# Altium schematic sheet HUB.SchDoc
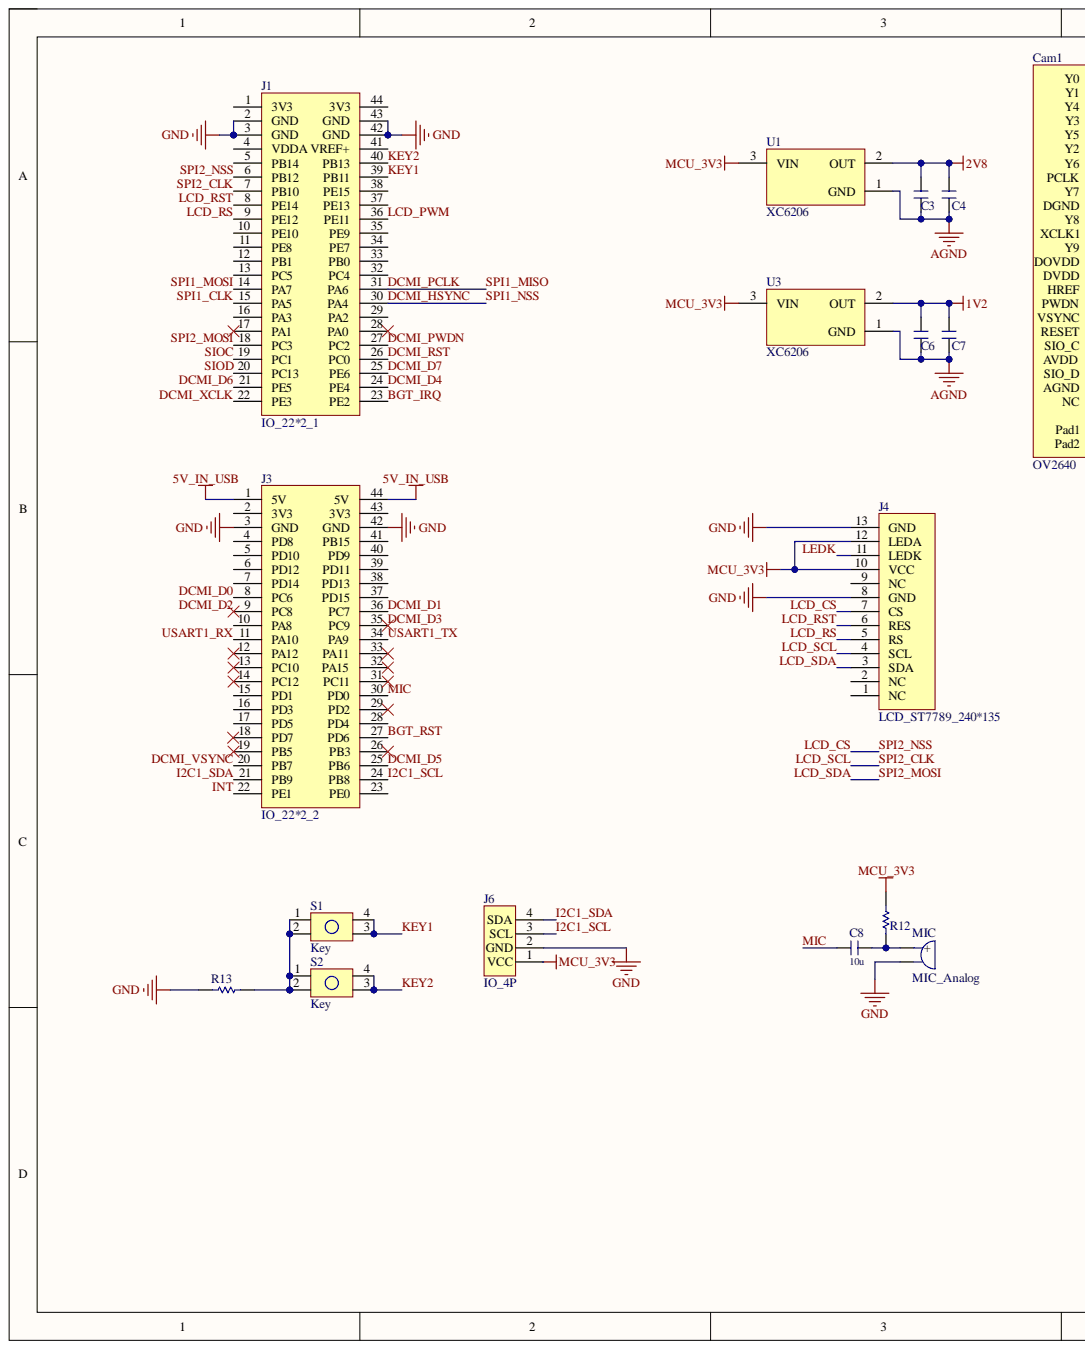
[diagram p0: HUB.SchDoc, left]
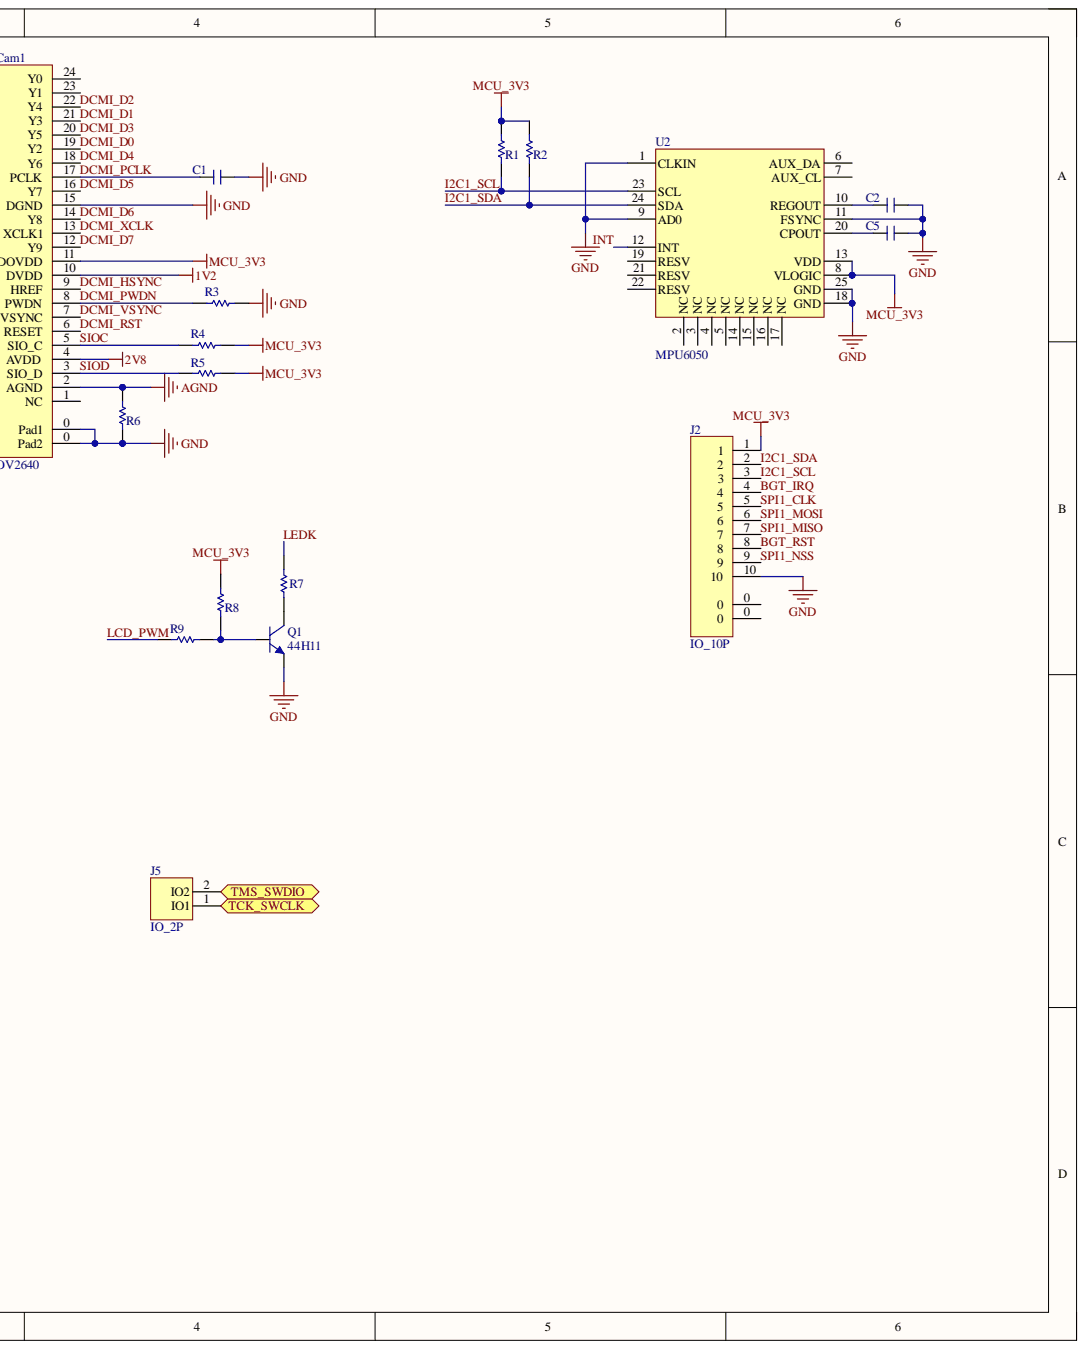
[diagram p1: HUB.SchDoc, right]

## Parts
C1  CAP  [0603_C_Axon]  (value not drawn)
C2  CAP  [0603_C_Axon]  (value not drawn)
C3  CAP  [0603_C_Axon]  (value not drawn)
C4  CAP  [0603_C_Axon]  (value not drawn)
C5  CAP  [0603_C_Axon]  (value not drawn)
C6  CAP  [0603_C_Axon]  (value not drawn)
C7  CAP  [0603_C_Axon]  (value not drawn)
C8  10u  [0603_C_Axon]
Cam1  OV2640  [FPC0.5 2H-WS-24P]
J1  IO_22*2_1  [IDE2X22]
J2  IO_10P  [FPC0.5 2H-WS-10P]
J3  IO_22*2_2  [IDE2X22]
J4  LCD_ST7789_240*135  [FPC_LCD_240*135]
J5  IO_2P  [HDR2.54-M-LI-2P]
J6  IO_4P  [ZH1.5-WS-4P]
MIC  MIC_Analog  [MIC]
Q1  44H11  [SOT_23_3_L_A]
R1  RES  [0603_R_Axon]  (value not drawn)
R2  RES  [0603_R_Axon]  (value not drawn)
R3  RES  [0603_R_Axon]  (value not drawn)
R4  RES  [0603_R_Axon]  (value not drawn)
R5  RES  [0603_R_Axon]  (value not drawn)
R6  RES  [0603_R_Axon]  (value not drawn)
R7  RES  [0603_R_Axon]  (value not drawn)
R8  RES  [0603_R_Axon]  (value not drawn)
R9  RES  [0603_R_Axon]  (value not drawn)
R12  RES  [0603_R_Axon]  (value not drawn)
R13  RES  [0603_R_Axon]  (value not drawn)
S1  Key  [BUTTON_SMD_4P]
S2  Key  [BUTTON_SMD_4P]
U1  XC6206  [SOT23-3N]
U2  MPU6050  [QFN_24]
U3  XC6206  [SOT23-3N]

## Ports
TCK_SWCLK, TMS_SWDIO

## Nets
1V2: C6.2 C7.2 Cam1.10(DVDD) U3.2
2V8: C3.2 C4.2 Cam1.4(AVDD) U1.2
5V_IN_USB: J3.1(5V) J3.44(5V)
AGND: C3.1 C4.1 C6.1 C7.1 Cam1.2(AGND) R6.2 U1.1 U3.1
GND: C1.2 C2.2 C5.2 Cam1.0(Pad2) Cam1.15(DGND) J1.2(GND) J1.3(GND) J1.42(GND) J1.43(GND) J2.10 J3.3(GND) J3.42(GND) J4.8(GND) J4.13(GND) J6.2(GND) MIC.2 Q1.3 R3.2 R6.1 R13.1 U2.1(CLKIN) U2.9(AD0) U2.11(FSYNC) U2.18(GND) U2.25(GND)
MCU_3V3: Cam1.11(DOVDD) J2.1 J4.10(VCC) J4.12(LEDA) J6.1(VCC) R1.1 R2.1 R4.2 R5.2 R8.2 R12.2 U1.3 U2.8(VLOGIC) U2.13(VDD) U3.3
BGT_IRQ: J1.23(PE2) J2.4
BGT_RST: J2.8 J3.27(PD6)
DCMI_D0: Cam1.19(Y2) J3.8(PC6)
DCMI_D1: Cam1.21(Y3) J3.36(PC7)
DCMI_D2: Cam1.22(Y4) J3.9(PC8)
DCMI_D3: Cam1.20(Y5) J3.35(PC9)
DCMI_D4: Cam1.18(Y6) J1.24(PE4)
DCMI_D5: Cam1.16(Y7) J3.25(PB6)
DCMI_D6: Cam1.14(Y8) J1.21(PE5)
DCMI_D7: Cam1.12(Y9) J1.25(PE6)
DCMI_HSYNC: Cam1.9(HREF) J1.30(PA4) J2.9
DCMI_PCLK: C1.1 Cam1.17(PCLK) J1.31(PA6) J2.7
DCMI_PWDN: Cam1.8(PWDN) J1.27(PC2) R3.1
DCMI_RST: Cam1.6(RESET) J1.26(PC0)
DCMI_VSYNC: Cam1.7(VSYNC) J3.20(PB7)
DCMI_XCLK: Cam1.13(XCLK1) J1.22(PE3)
I2C1_SCL: J2.3 J3.24(PB8) J6.3(SCL) R1.2 U2.23(SCL)
I2C1_SDA: J2.2 J3.21(PB9) J6.4(SDA) R2.2 U2.24(SDA)
INT: J3.22(PE1) U2.12(INT)
KEY1: J1.39(PB11) S1.3 S1.4
KEY2: J1.40(PB13) S2.3 S2.4
LCD_CS: J1.6(PB12) J4.7(CS)
LCD_PWM: J1.36(PE11) R9.1
LCD_RS: J1.9(PE12) J4.5(RS)
LCD_RST: J1.8(PE14) J4.6(RES)
LCD_SCL: J1.7(PB10) J4.4(SCL)
LCD_SDA: J1.18(PC3) J4.3(SDA)
LEDK: J4.11(LEDK) R7.2
MIC: C8.1 J3.30(PD0)
SIOC: Cam1.5(SIO_C) J1.19(PC1) R4.1
SIOD: Cam1.3(SIO_D) J1.20(PC13) R5.1
SPI1_CLK: J1.15(PA5) J2.5
SPI1_MOSI: J1.14(PA7) J2.6
TCK_SWCLK: J5.1 ; port TCK_SWCLK
TMS_SWDIO: J5.2 ; port TMS_SWDIO
USART1_RX: J3.11(PA10)
USART1_TX: J3.34(PA9)
NetC2_1: C2.1 U2.10(REGOUT)
NetC5_1: C5.1 U2.20(CPOUT)
NetC8_2: C8.2 MIC.1 R12.1
NetQ1_1: Q1.1 R8.1 R9.2
NetQ1_2: Q1.2 R7.1
NetR13_2: R13.2 S1.1 S1.2 S2.1 S2.2

Not connected: Cam1 1(NC) 23(Y1) 24(Y0); J1 1(3V3) 4(VDDA) 5(PB14) 10(PE10) 11(PE8) 12(PB1) 13(PC5) 16(PA3) 17(PA1) 28(PA0) 29(PA2) 32(PC4) 33(PB0) 34(PE7) 35(PE9) 37(PE13) 38(PE15) 41(VREF+) 44(3V3); J2 0; J3 2(3V3) 4(PD8) 5(PD10) 6(PD12) 7(PD14) 10(PA8) 12(PA12) 13(PC10) 14(PC12) 15(PD1) 16(PD3) 17(PD5) 18(PD7) 19(PB5) 23(PE0) 26(PB3) 28(PD4) 29(PD2) 31(PC11) 32(PA15) 33(PA11) 37(PD15) 38(PD13) 39(PD11) 40(PD9) 41(PB15) 43(3V3); J4 1(NC) 2(NC) 9(NC); U2 2(NC) 3(NC) 4(NC) 5(NC) 6(AUX_DA) 7(AUX_CL) 14(NC) 15(NC) 16(NC) 17(NC) 19(RESV) 21(RESV) 22(RESV)

## Library data of the parts
C1-C8 = CAP: 电容; Component Kind: Standard
  C1-C7: Library Name: MySchLib.SchLib
  C8: Library Name: esp8266_max7219.SchLib
Cam1 = OV2640: 摄像头; Component Kind: Standard; Library Name: MySchLib.SchLib
J1 = IO_22*2_1: F407VET6 IOs; Component Kind: Standard; Library Name: MySchLib.SchLib
J3 = IO_22*2_2: F407VET6 IOs; Component Kind: Standard; Library Name: MySchLib.SchLib
MIC = MIC_Analog: 麦克风; Component Kind: Standard; LatestRevisionDate: 17-Jul-2002; LatestRevisionNote: Re-released for DXP Platform.; Library Name: MySchLib.SchLib; PackageReference: PIN2; Published: 24-Mar-1999; Publisher: Altium Limited
Q1 = 44H11: 互补功率-NPN型; 属性: 80V 8A 50W; 版权归属: 封装库来自淘宝 ID：黄晶宝石; BOM名称: 互补功率-NPN型; Component Kind: Standard; Library Name: MySchLib.SchLib; 技术支持，联系售后: https://shop463601444.taobao.com
R1-R9 R12 R13 = RES: 电阻; Component Kind: Standard; LatestRevisionDate: 17-Jul-2002; LatestRevisionNote: Re-released for DXP Platform.; Library Name: MySchLib.SchLib; PackageReference: AXIAL-0.3; Published: 8-Jun-2000; Publisher: Altium Limited
S1 S2 = Key: 开关; Component Kind: Standard; Library Name: esp8266_max7219.SchLib
U1 U3 = XC6206: 稳压芯片; Component Kind: Standard; Library Name: MySchLib.SchLib
J2 = IO_10P: Component Kind: Standard; Library Name: MySchLib.SchLib
J4 = LCD_ST7789_240*135: Component Kind: Standard; Library Name: MySchLib.SchLib
J5 = IO_2P: Component Kind: Standard; Library Name: MySchLib.SchLib
J6 = IO_4P: Component Kind: Standard; Library Name: MySchLib.SchLib
U2 = MPU6050: Component Kind: Standard; Library Name: esp8266_max7219.SchLib
